# Altium schematic sheet Gate_Drivers.SchDoc(Gate_Drivers)
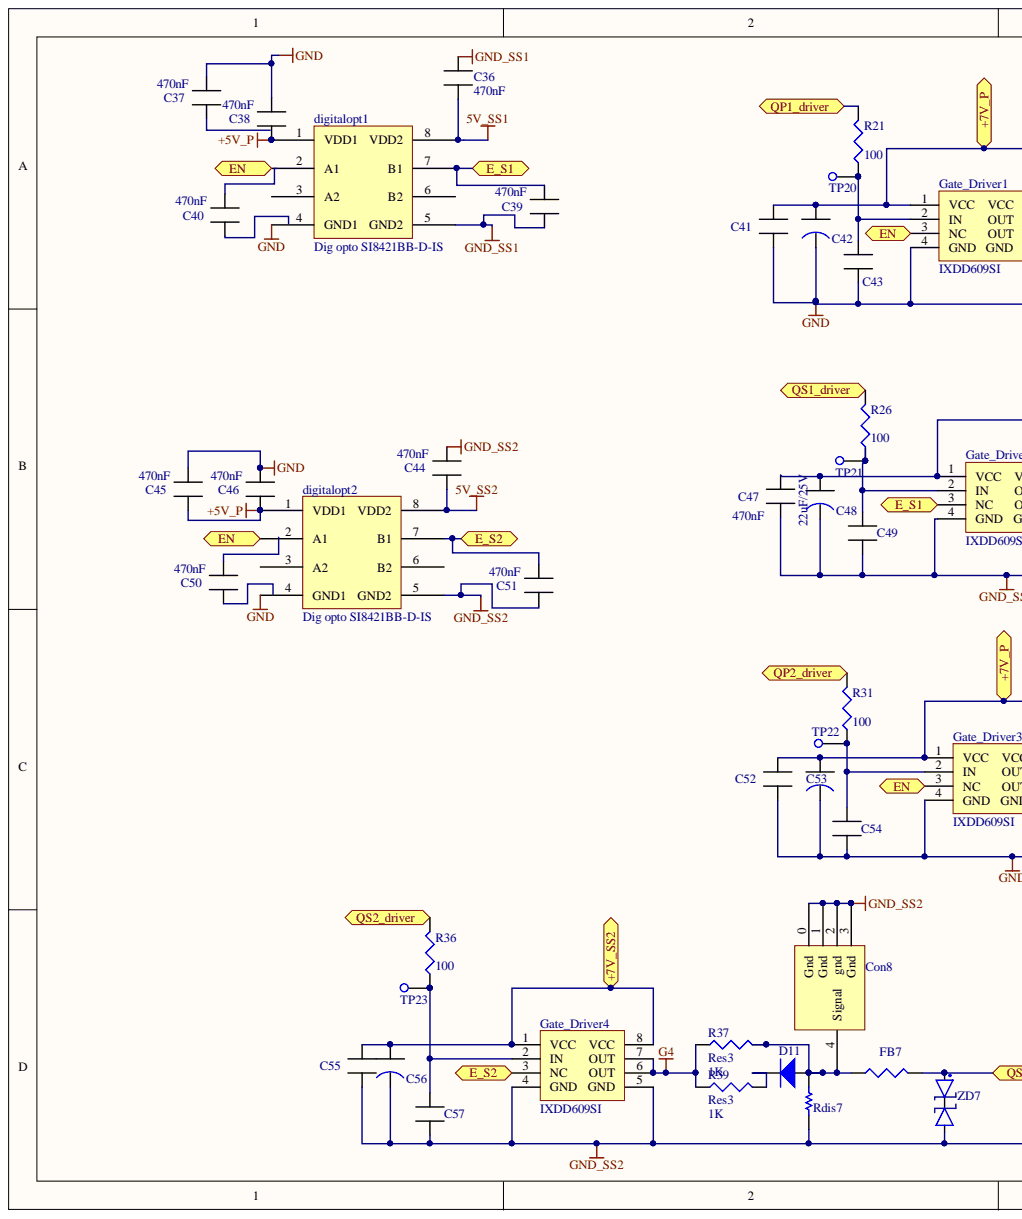
[diagram p0: Gate_Drivers.SchDoc, left]
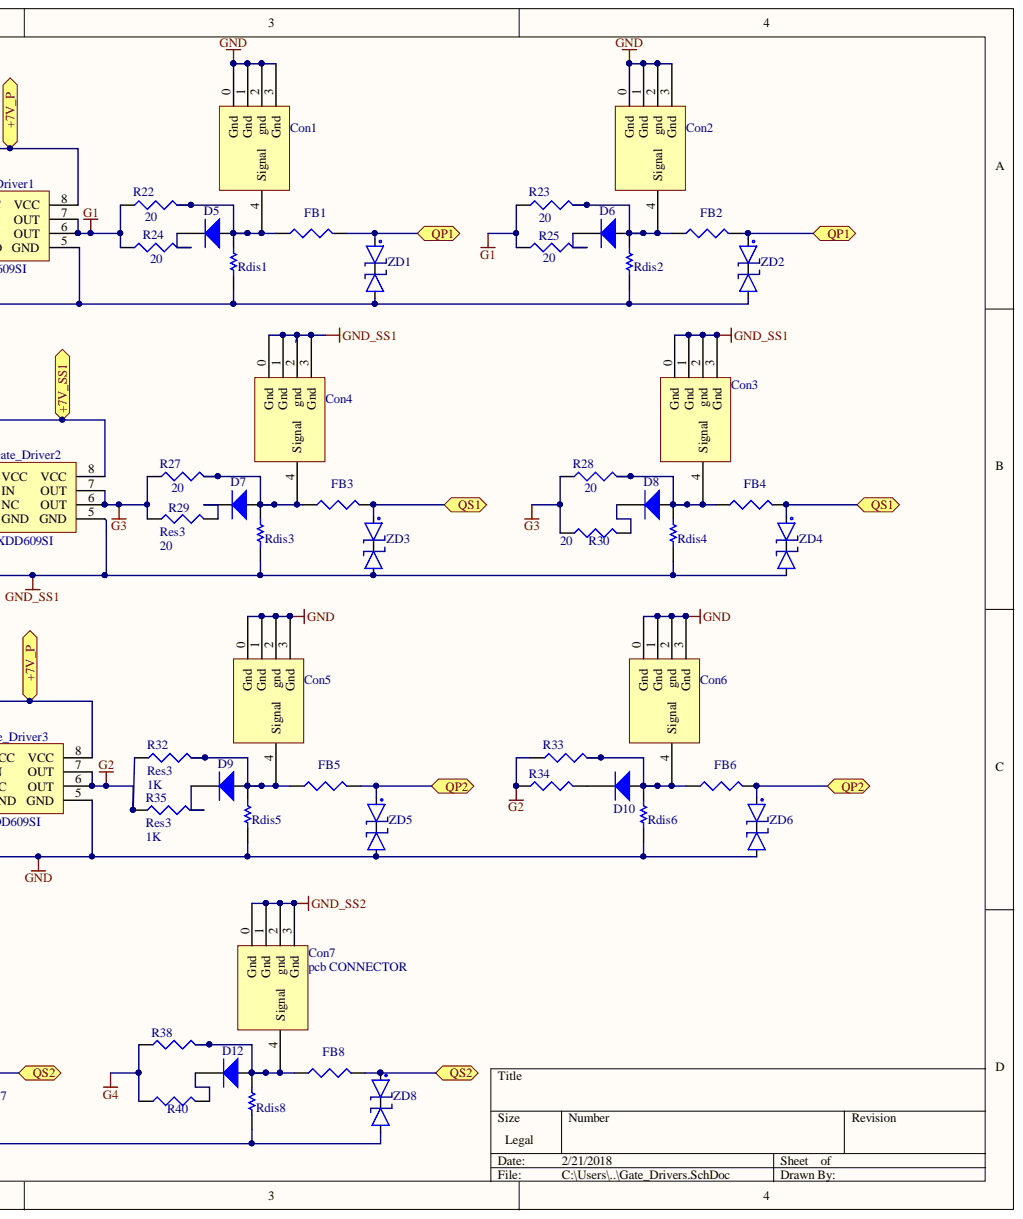
[diagram p1: Gate_Drivers.SchDoc, right]

## Parts
C36  100nF  [Cap_SMD]  (value not drawn)
C37  100nF  [Cap_SMD]  (value not drawn)
C38  100nF  [Cap_SMD]  (value not drawn)
C39  100nF  [Cap_SMD]  (value not drawn)
C40  100nF  [Cap_SMD]  (value not drawn)
C41  100nF  [Cap_SMD]  (value not drawn)
C42  22uF  [Cap_tantalum]  (value not drawn)
C43  100nF  [Cap_SMD]  (value not drawn)
C44  100nF  [Cap_SMD]  (value not drawn)
C45  100nF  [Cap_SMD]  (value not drawn)
C46  100nF  [Cap_SMD]  (value not drawn)
C47  100nF  [Cap_SMD]  (value not drawn)
C48  22uF/25V  [Cap_tantalum]
C49  100nF  [Cap_SMD]  (value not drawn)
C50  100nF  [Cap_SMD]  (value not drawn)
C51  100nF  [Cap_SMD]  (value not drawn)
C52  100nF  [Cap_SMD]  (value not drawn)
C53  22uF  [Cap_tantalum]  (value not drawn)
C54  100nF  [Cap_SMD]  (value not drawn)
C55  100nF  [Cap_SMD]  (value not drawn)
C56  22uF  [Cap_tantalum]  (value not drawn)
C57  100nF  [Cap_SMD]  (value not drawn)
Con1  Component_1  [PCBComponent_1]  (value not drawn)
Con2  Component_1  [PCBComponent_1]  (value not drawn)
Con3  pcb CONNECTOR  [PCB_CONNECTOR]
Con4  Component_1  [PCBComponent_1]  (value not drawn)
Con5  Component_1  [PCBComponent_1]  (value not drawn)
Con6  Component_1  [PCBComponent_1]  (value not drawn)
Con7  pcb CONNECTOR  [PCB_CONNECTOR]
Con8  Component_1  [PCBComponent_1]  (value not drawn)
D5  PMEG2010EPK  [PMEG2010EPK]  (value not drawn)
D6  PMEG2010EPK  [PMEG2010EPK]  (value not drawn)
D7  PMEG2010EPK  [PMEG2010EPK]  (value not drawn)
D8  PMEG2010EPK  [PMEG2010EPK]  (value not drawn)
D9  PMEG2010EPK  [PMEG2010EPK]  (value not drawn)
D10  PMEG2010EPK  [PMEG2010EPK]  (value not drawn)
D11  PMEG2010EPK  [PMEG2010EPK]  (value not drawn)
D12  PMEG2010EPK  [PMEG2010EPK]  (value not drawn)
digitalopt1  Dig opto SI8421BB-D-IS  [SO8 TS27l2]
digitalopt2  Dig opto SI8421BB-D-IS  [SO8 TS27l2]
FB1  Res3  [J1-0603]  (value not drawn)
FB2  Res3  [J1-0603]  (value not drawn)
FB3  Res3  [J1-0603]  (value not drawn)
FB4  Res3  [J1-0603]  (value not drawn)
FB5  Res3  [J1-0603]  (value not drawn)
FB6  Res3  [J1-0603]  (value not drawn)
FB7  Res3  [J1-0603]  (value not drawn)
FB8  Res3  [J1-0603]  (value not drawn)
Gate_Driver1  IXDD609SI  [Gate driver _low side]
Gate_Driver2  IXDD609SI  [Gate driver _low side]
Gate_Driver3  IXDD609SI  [Gate driver _low side]
Gate_Driver4  IXDD609SI  [Gate driver _low side]
R21  value 100  [J1-0603]  (hidden comment: Res3)
R22  value 20  [J1-0603]  (hidden comment: Res3)
R23  value 20  [J1-0603]  (hidden comment: Res3)
R24  value 20  [J1-0603]  (hidden comment: Res3)
R25  20  [J1-0603]
R26  value 100  [J1-0603]  (hidden comment: Res3)
R27  value 20  [J1-0603]  (hidden comment: Res3)
R28  value 20  [J1-0603]  (hidden comment: Res3)
R29  Res3  value 20  [J1-0603]
R30  20  [J1-0603]
R31  value 100  [J1-0603]  (hidden comment: Res3)
R32  Res3  [J1-0603]
R33  Res3  [J1-0603]  (value not drawn)
R34  Res3  [J1-0603]  (value not drawn)
R35  Res3  value 1K  [J1-0603]
R36  value 100  [J1-0603]  (hidden comment: Res3)
R37  Res3  [J1-0603]
R38  Res3  [J1-0603]  (value not drawn)
R39  Res3  value 1K  [J1-0603]
R40  Res3  [J1-0603]  (value not drawn)
Rdis1  res_smd  [res_smd]  (value not drawn)
Rdis2  res_smd  [res_smd]  (value not drawn)
Rdis3  res_smd  [res_smd]  (value not drawn)
Rdis4  res_smd  [res_smd]  (value not drawn)
Rdis5  res_smd  [res_smd]  (value not drawn)
Rdis6  res_smd  [res_smd]  (value not drawn)
Rdis7  res_smd  [res_smd]  (value not drawn)
Rdis8  res_smd  [res_smd]  (value not drawn)
TP20  Test Point  [Test Point]  (value not drawn)
TP21  Test Point  [Test Point]  (value not drawn)
TP22  Test Point  [Test Point]  (value not drawn)
TP23  Test Point  [Test Point]  (value not drawn)
ZD1  8.2 v  [Zener Diode]  (value not drawn)
ZD2  8.2 v  [Zener Diode]  (value not drawn)
ZD3  8.2 v  [Zener Diode]  (value not drawn)
ZD4  8.2 v  [Zener Diode]  (value not drawn)
ZD5  8.2 v  [Zener Diode]  (value not drawn)
ZD6  8.2 v  [Zener Diode]  (value not drawn)
ZD7  8.2 v  [Zener Diode]  (value not drawn)
ZD8  8.2 v  [Zener Diode]  (value not drawn)

## Ports
+7V_P, +7V_SS1, +7V_SS2, E_S1, E_S2, EN, QP1, QP1_driver, QP2, QP2_driver, QS1, QS1_driver, QS2, QS2_driver

## Nets
5V_SS1: C36.1 digitalopt1.8(VDD2)
5V_SS2: C44.2 digitalopt2.8(VDD2)
+5V_P: C38.2 C45.2 C46.2 digitalopt1.1(VDD1) digitalopt2.1(VDD1)
G1: Gate_Driver1.6(OUT) Gate_Driver1.7(OUT) R22.1 R23.1 R24.1 R25.1
G2: Gate_Driver3.6(OUT) Gate_Driver3.7(OUT) R32.1 R33.1 R34.1 R35.1
G3: Gate_Driver2.6(OUT) Gate_Driver2.7(OUT) R27.1 R28.1 R29.1 R30.1
G4: Gate_Driver4.6(OUT) Gate_Driver4.7(OUT) R37.1 R38.1 R39.1 R40.1
GND: C37.1 C38.1 C41.2 C42.2 C43.2 C45.1 C46.1 C52.2 C53.2 C54.2 Con1.0(Gnd) Con1.1(Gnd) Con1.2(gnd) Con1.3(Gnd) Con2.0(Gnd) Con2.1(Gnd) Con2.2(gnd) Con2.3(Gnd) Con5.0(Gnd) Con5.1(Gnd) Con5.2(gnd) Con5.3(Gnd) Con6.0(Gnd) Con6.1(Gnd) Con6.2(gnd) Con6.3(Gnd) digitalopt1.4(GND1) digitalopt2.4(GND1) Gate_Driver1.4(GND) Gate_Driver1.5(GND) Gate_Driver3.4(GND) Gate_Driver3.5(GND) Rdis1.2 Rdis2.2 Rdis5.2 Rdis6.2 ZD1.2 ZD2.2 ZD5.2 ZD6.2
GND_SS1: C36.2 C39.2 C47.2 C48.2 C49.2 Con3.0(Gnd) Con3.1(Gnd) Con3.2(gnd) Con3.3(Gnd) Con4.0(Gnd) Con4.1(Gnd) Con4.2(gnd) Con4.3(Gnd) digitalopt1.5(GND2) Gate_Driver2.4(GND) Gate_Driver2.5(GND) Rdis3.2 Rdis4.2 ZD3.2 ZD4.2
GND_SS2: C44.1 C51.2 C55.2 C56.2 C57.2 Con7.0(Gnd) Con7.1(Gnd) Con7.2(gnd) Con7.3(Gnd) Con8.0(Gnd) Con8.1(Gnd) Con8.2(gnd) Con8.3(Gnd) digitalopt2.5(GND2) Gate_Driver4.4(GND) Gate_Driver4.5(GND) Rdis7.2 Rdis8.2 ZD7.2 ZD8.2
+7V_P: C41.1 C42.1 C52.1 C53.1 Gate_Driver1.1(VCC) Gate_Driver1.8(VCC) Gate_Driver3.1(VCC) Gate_Driver3.8(VCC) ; port +7V_P
+7V_SS1: C47.1 C48.1 Gate_Driver2.1(VCC) Gate_Driver2.8(VCC) ; port +7V_SS1
+7V_SS2: C55.1 C56.1 Gate_Driver4.1(VCC) Gate_Driver4.8(VCC) ; port +7V_SS2
E_S1: C39.1 digitalopt1.7(B1) Gate_Driver2.3(NC) ; port E_S1
E_S2: C51.1 digitalopt2.7(B1) Gate_Driver4.3(NC) ; port E_S2
EN: digitalopt1.2(A1) digitalopt2.2(A1) Gate_Driver1.3(NC) Gate_Driver3.3(NC) ; port EN
QP1: FB1.2 FB2.2 ZD1.1 ZD2.1 ; port QP1
QP1_driver: R21.2 ; port QP1_driver
QP2: FB5.2 FB6.2 ZD5.1 ZD6.1 ; port QP2
QP2_driver: R31.2 ; port QP2_driver
QS1: FB3.2 FB4.2 ZD3.1 ZD4.1 ; port QS1
QS1_driver: R26.2 ; port QS1_driver
QS2: FB7.2 FB8.2 ZD7.1 ZD8.1 ; port QS2
QS2_driver: R36.2 ; port QS2_driver
NetC40_1: C40.1
NetC43_1: C43.1 Gate_Driver1.2(IN) R21.1 TP20.1
NetC49_1: C49.1 Gate_Driver2.2(IN) R26.1 TP21.1
NetC54_1: C54.1 Gate_Driver3.2(IN) R31.1 TP22.1
NetC57_1: C57.1 Gate_Driver4.2(IN) R36.1 TP23.1
NetCon1_4: Con1.4(Signal) D5.1 FB1.1 R22.2 Rdis1.1
NetCon2_4: Con2.4(Signal) D6.1 FB2.1 R23.2 Rdis2.1
NetCon3_4: Con3.4(Signal) D8.1 FB4.1 R28.2 Rdis4.1
NetCon4_4: Con4.4(Signal) D7.1 FB3.1 R27.2 Rdis3.1
NetCon5_4: Con5.4(Signal) D9.1 FB5.1 R32.2 Rdis5.1
NetCon6_4: Con6.4(Signal) D10.1 FB6.1 R33.2 Rdis6.1
NetCon7_4: Con7.4(Signal) D12.1 FB8.1 R38.2 Rdis8.1
NetCon8_4: Con8.4(Signal) D11.1 FB7.1 R37.2 Rdis7.1
NetD5_0: D5.0 R24.2
NetD6_0: D6.0 R25.2
NetD7_0: D7.0 R29.2
NetD8_0: D8.0 R30.2
NetD9_0: D9.0 R35.2
NetD10_0: D10.0 R34.2
NetD11_0: D11.0 R39.2
NetD12_0: D12.0 R40.2

Not connected: C37 2; C40 2; C50 1 2; digitalopt1 3(A2) 6(B2); digitalopt2 3(A2) 6(B2); ZD1 3; ZD2 3; ZD3 3; ZD4 3; ZD5 3; ZD6 3; ZD7 3; ZD8 3

## Library data of the parts
C36-C41 C43-C47 C49-C52 C54 C55 C57 = Cap_SMD: Library Name: Schlib1.SchLib; Component Kind: Standard
  C36-C40 C44-C47 C50 C51: : 470nF
C42 C48 C53 C56 = Cap_tantalum: Library Name: Schlib1.SchLib; Component Kind: Standard
digitalopt1 digitalopt2 = Digital Isolator: Library Name: control Board.SchLib; Component Kind: Standard
FB1-FB8 R25 R30 R32-R34 R37 R38 R40 = Res3: Resistor; Value: 1K; Simulation: RESISTOR; Signal Integrity: Res; Published: 8-Jun-2000; PackageReference: J1-0603; PackageDescription: Chip Resistor; Library Name: Miscellaneous Devices.IntLib; LatestRevisionNote: IPC-7351 Footprint Added.; LatestRevisionDate: 29-May-2009; Component Kind: Standard; Publisher: Altium Limited
Gate_Driver1-Gate_Driver4 = Gate driver low side: Component Kind: Standard; Library Name: Gan_cuk converter.SchLib
R21-R24 R26-R29 R31 R35 R36 R39 = Res3: Resistor; Simulation: RESISTOR; Signal Integrity: Res; Published: 8-Jun-2000; PackageReference: J1-0603; PackageDescription: Chip Resistor; Library Name: Miscellaneous Devices.IntLib; LatestRevisionNote: IPC-7351 Footprint Added.; LatestRevisionDate: 29-May-2009; Component Kind: Standard; Publisher: Altium Limited
Rdis1-Rdis8 = res_smd: smd_res; Library Name: Schlib1.SchLib; Component Kind: Standard
ZD1-ZD8 = Zener_diode: DZ23C8V2-7-F; Component Kind: Standard; Library Name: Gan_cuk converter.SchLib
Con1 Con2 Con4-Con6 Con8 = Component_1: Library Name: pcb_connector.SchLib; Component Kind: Standard
Con3 Con7 = pcb CONNECTOR: Component Kind: Standard; Library Name: lib_ankit.SchLib
D5-D12 = PMEG2010EPK: Description: PMEG2010EPK; Component Kind: Standard; Library Name: lib_ankit.SchLib
TP20-TP23 = Test Point: Component Kind: Standard; Library Name: Gan_cuk converter.SchLib
